# Altium schematic sheet POWER.SchDoc
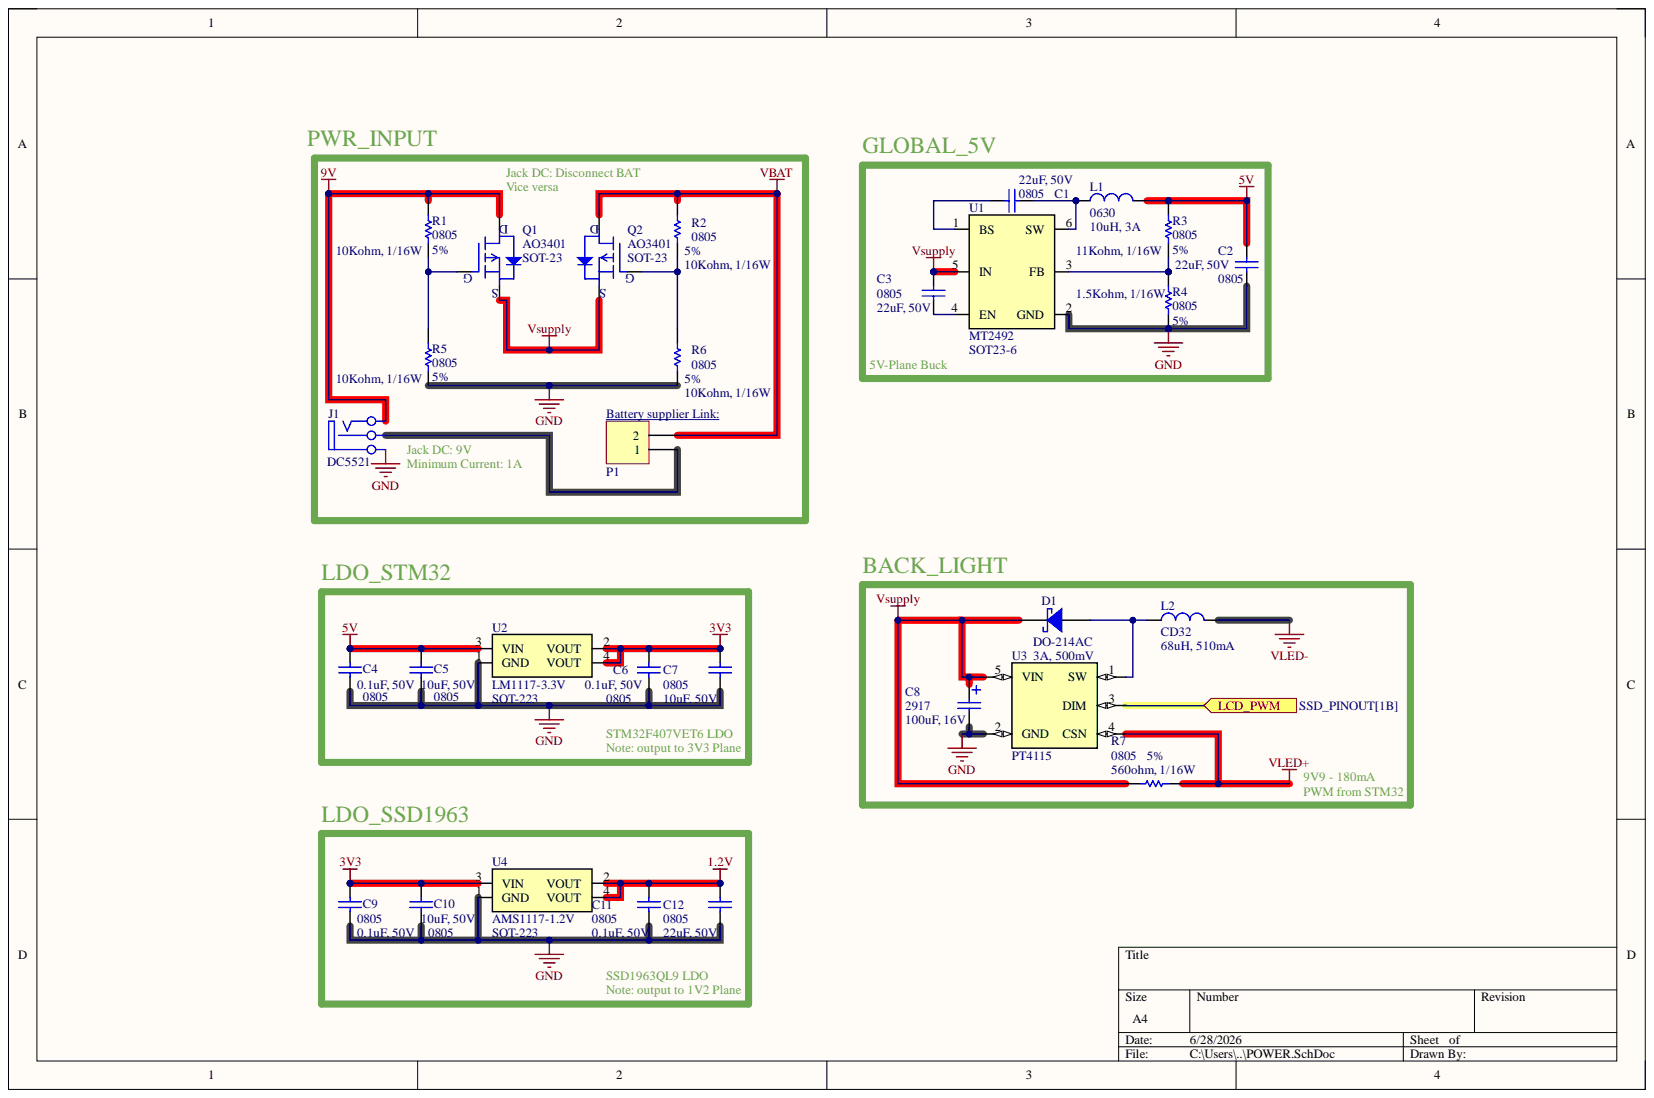
[diagram p0: POWER.SchDoc]

## Parts
C1  22uF, 50V  Package: 0805  [C_0805]
C2  22uF, 50V  Package: 0805  [C_0805]
C3  22uF, 50V  Package: 0805  [C_0805]
C4  0.1uF, 50V  Package: 0805  [C_0805]
C5  10uF, 50V  Package: 0805  [C_0805]
C6  0.1uF, 50V  Package: 0805  [C_0805]
C7  10uF, 50V  Package: 0805  [C_0805]
C8  100uF, 16V  [C_2917+]
C9  0.1uF, 50V  Package: 0805  [C_0805]
C10  10uF, 50V  Package: 0805  [C_0805]
C11  0.1uF, 50V  Package: 0805  [C_0805]
C12  22uF, 50V  Package: 0805  [C_0805]
D1  3A, 500mV  Package: DO-214AC  [PKG_SMA (DO-214AC)]
J1  DC5521  [CONN_DC5521]
L1  10uH, 3A  Package: 0630  [COIL_74]
L2  68uH, 510mA  Package: CD32  [COIL_CD32]
P1  Header 2  [JP2]  (value not drawn)
Q1  AO3401  Package: SOT-23  [PKG_SOT-23]
Q2  AO3401  Package: SOT-23  [PKG_SOT-23]
R1  10Kohm, 1/16W  Acc: 5%  Package: 0805  [R_0805]
R2  10Kohm, 1/16W  Acc: 5%  Package: 0805  [R_0805]
R3  11Kohm, 1/16W  Acc: 5%  Package: 0805  [R_0805]
R4  1.5Kohm, 1/16W  Acc: 5%  Package: 0805  [R_0805]
R5  10Kohm, 1/16W  Acc: 5%  Package: 0805  [R_0805]
R6  10Kohm, 1/16W  Acc: 5%  Package: 0805  [R_0805]
R7  560ohm, 1/16W  Acc: 5%  Package: 0805  [R_0805]
U1  MT2492  Package: SOT23-6  [PKG-SOT23-6]
U2  LM1117-3.3V  Package: SOT-223  [PKG_SOT-223]
U3  PT4115  [PKG_SOT-89-5]
U4  AMS1117-1.2V  Package: SOT-223  [PKG_SOT-223]

## Ports
LCD_PWM

## Nets
1.2V: C11.2 C12.2 U4.2(VOUT) U4.4(VOUT)
3V3: C6.2 C7.2 C9.2 C10.2 U2.2(VOUT) U2.4(VOUT) U4.3(VIN)
5V: C2.1 C4.2 C5.2 L1.2 R3.2 U2.3(VIN)
9V: J1.1 Q1.3 R1.2
GND: C2.2 C4.1 C5.1 C6.1 C7.1 C8.1 C9.1 C10.1 C11.1 C12.1 J1.2 R4.1 R5.1 R6.1 U1.2(GND) U2.1(GND) U3.2(GND) U4.1(GND)
VBAT: P1.2 Q2.3 R2.2
VLED+: R7.1 U3.4(CSN)
VLED-: L2.2
Vsupply: C3.1 C8.2 D1.1 Q1.2 Q2.2 R7.2 U1.5(IN) U3.5(VIN)
LCD_PWM: U3.3(DIM) ; port LCD_PWM
NetC1_1: C1.1 U1.1(BS)
NetC1_2: C1.2 L1.1 U1.6(SW)
NetC3_2: C3.2 U1.4(EN)
NetD1_2: D1.2 L2.1 U3.1(SW)
NetJ1_3: J1.3 P1.1
NetQ1_1: Q1.1 R1.1 R5.2
NetQ2_1: Q2.1 R2.1 R6.2
NetR3_1: R3.1 R4.2 U1.3(FB)

## Library data of the parts
C1-C7 C9-C12 = CAP_0805: Manufacturer 1: Vishay; Manufacturer Part Number 1: CAP0805; Component Kind: Standard; Datasheet: https://www.mouser.de/datasheet/2/447/PYu_RC_Group_51_RoHS_L_11-1984063.pdf; Description: CAP_0805; Library Name: DisplayLib.IntLib; Supplier 1: Thegioiic; Supplier Link: N/a
  C1-C3 C12: Value: 22uF, 50V
  C4 C6 C9 C11: Value: 0.1uF, 50V
  C5 C7 C10: Value: 10uF, 50V
C8 = CAP+2917: Manufacturer 1: OEM; Manufacturer Part Number 1: N/a; Component Kind: Standard; Datasheet: N/a; Description: CAP+2917; Library Name: DisplayLib.IntLib; Package: 2917; Supplier 1: Thegioiic; Supplier Link: N/a; Value: 100uF, 16V
D1 = SCHOTTKY: SS34; Manufacturer 1: OEM; Manufacturer Part Number 1: N/a; Component Kind: Standard; ComponentLink1Description: Datasheet; ComponentLink1URL: https://www.vishay.com/doc?89055; Datasheet: N/a; Library Name: DisplayLib.IntLib; Supplier 1: Thegioiic; Supplier Link: N/a; Value: 3A, 500mV
J1 = DC5521: Jack DC; Manufacturer 1: OEM; Component Kind: Standard; Datasheet: N/a; Library Name: DisplayLib.IntLib; Manufacturer Part Number 1: DC5521; Supplier 1: Thegioiic; Supplier Link: https://www.thegioiic.com/dc5521-dau-noi-nguon-dc-cai-5521-han-pcb-kim-2-1mm
L1 = IN_0630: Manufacturer 1: OEM; Manufacturer Part Number 1: N/a; Component Kind: Standard; ComponentLink1Description: Datasheet; ComponentLink1URL: https://product.tdk.com/system/files/dam/doc/product/inductor/inductor/smd/catalog/inductor_automotive_decoupling_mlz1608_en.pdf?ref_disty=mouser; ComponentLink2Description: Supplier 1 URL; ComponentLink2URL: https://www.mouser.vn/ProductDetail/TDK/MLZ1608M100WTD25?qs=u4%252BEJ9urDATpNhOvJ54DAQ%3D%3D; ComponentLink3Description: Supplier 2 URL; ComponentLink3URL: https://www.digikey.com/en/products/detail/tdk-corporation/MLZ1608M100WTD25/4743176; ComponentLink4Description: Supplier 3 URL; Datasheet: N/a; Description: IN_0630; Library Name: DisplayLib.IntLib; Supplier 1: Thegioiic; Supplier Link: N/a; Value: 10uH, 3A
L2 = IN_CD32: Manufacturer 1: OEM; Manufacturer Part Number 1: N/a; Component Kind: Standard; ComponentLink1Description: Datasheet; ComponentLink1URL: https://product.tdk.com/system/files/dam/doc/product/inductor/inductor/smd/catalog/inductor_automotive_decoupling_mlz1608_en.pdf?ref_disty=mouser; ComponentLink2Description: Supplier 1 URL; ComponentLink2URL: https://www.mouser.vn/ProductDetail/TDK/MLZ1608DR10DTD25?qs=u4%252BEJ9urDARQOELDKhLR2A%3D%3D; ComponentLink3Description: Supplier 2 URL; ComponentLink3URL: https://www.digikey.com/en/products/detail/tdk-corporation/MLZ1608DR10DTD25/4743170; ComponentLink4Description: Supplier 3 URL; Datasheet: N/a; Description: IN_CD32; Library Name: DisplayLib.IntLib; Supplier 1: Thegioiic; Supplier Link: N/a; Value: 68uH, 510mA
P1 = Header 2: Header, 2-Pin; Component Kind: Standard; LatestRevisionDate: 17-Jul-2002; LatestRevisionNote: Re-released for DXP Platform.; Library Name: PIF_Library.IntLib; Publisher: Altium Limited
Q1 Q2 = AO3401: P-Channel 30 V 4A (Ta) 1.4W (Ta) Surface Mount SOT-23-3; Manufacturer 1: Alpha&Omega Semi.; Component Kind: Standard; Datasheet: https://www.snapeda.com/parts/AO3401/Alpha%20&%20Omega%20Semiconductor/datasheet/; Library Name: DisplayLib.IntLib; Manufacturer Part Number 1: AO3401; Supplier 1: ICDayroi; Supplier Link: https://icdayroi.com/ao3401
R1-R7 = RES_0805: Manufacturer 1: Vishay; Manufacturer Part Number 1: RES0805; Component Kind: Standard; Datasheet: https://www.mouser.de/datasheet/2/447/PYu_RC_Group_51_RoHS_L_11-1984063.pdf; Description: RES_0805; Library Name: DisplayLib.IntLib; Supplier 1: Thegioiic; Supplier Link: N/a
  R1 R2 R5 R6: Value: 10Kohm, 1/16W
  R3: Value: 11Kohm, 1/16W
  R4: Value: 1.5Kohm, 1/16W
  R7: Value: 560ohm, 1/16W
U1 = MT2492: 600KHz, 16V，2A Synchronous  Step-Down Converter; Manufacturer 1: AEROSEMI; Component Kind: Standard; Datasheet: https://images.tuyacn.com/smart/A_TUYA/camera/MT2492.pdf; Library Name: DisplayLib.IntLib; Manufacturer Part Number 1: MT2492; Supplier 1: linhkienthuduc; Supplier Link: https://linhkienthuduc.com/san-pham/mt2492-ic-nguon-buck-2a/?srsltid=AfmBOoqjhnkSrAs4LOHLVGH4jS9BsyeN6OqcnkH62NuZAXu-ngXV-6yD
U2 = LM1117-3.3V: 800mA Low-Dropout Linear Regulator; Manufacturer 1: TI; Component Kind: Standard; Datasheet: https://www.ti.com/lit/ds/symlink/lm1117.pdf; Library Name: DisplayLib.IntLib; Manufacturer Part Number 1: LM1117-3.3V; Supplier 1: Thegioiic; Supplier Link: https://www.thegioiic.com/lm1117imp-3-3-nopb-cn-ic-on-ap-3-3v-800ma-sot-223
U3 = PT4115: 0V, 1.2A Step-down High Brightness  LED Driver with 5000:1 Dimming; Manufacturer 1: PowTech; Component Kind: Standard; Datasheet: https://www.microelectronicash.com/downloads/PT4115.pdf; Library Name: DisplayLib.IntLib; Manufacturer Part Number 1: PT4115; Supplier 1: icdayroi; Supplier Link: https://icdayroi.com/pt4115-pt4115b89e-sot-89-5
U4 = AMS1117-1.2V: 1A Adjustable/Fixed Low Dropout Linear Regulator; Manufacturer 1: AMS; Component Kind: Standard; Datasheet: http://www.ams-semitech.com/attachments/File/AMS1117_20120314.pdf; Library Name: DisplayLib.IntLib; Manufacturer Part Number 1: AMS1117-1.2V; Supplier 1: Thegioiic; Supplier Link: https://www.thegioiic.com/ams1117-1-2v-ic-on-ap-1-2v-1a-sot-223
